# Altium schematic sheet mems.SchDoc
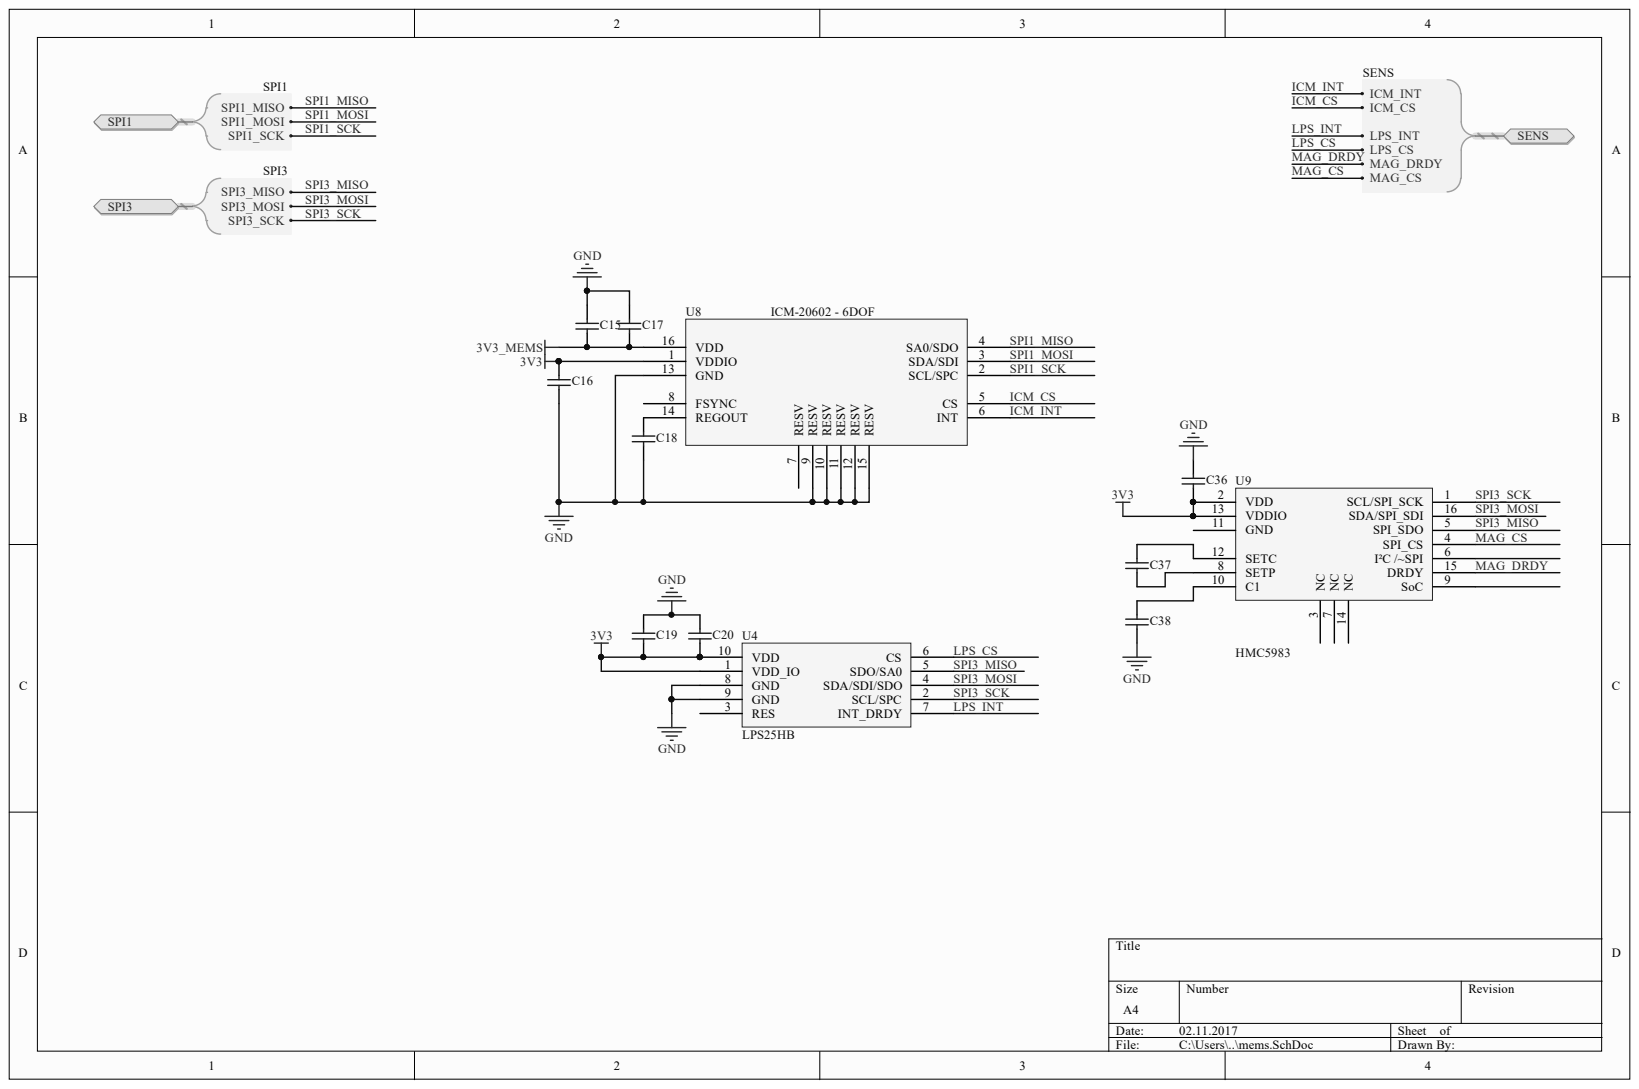
[diagram p0: mems.SchDoc]

## Parts
C15  CAP 100nF 35V 0402(1005)  [CAPC0402(1005)60_M]  (value not drawn)
C16  CAP 10nF 35V 0402(1005)  [CAPC0402(1005)60_M]  (value not drawn)
C17  CAP 2.2uF 16V 0603(1608)  [CAPC0603(1608)55_L]  (value not drawn)
C18  CAP 100nF 25V 0402(1005)  [CAPC0402(1005)60_L]  (value not drawn)
C19  CAP 1uF 6.3V 0603(1608)  [CAPC0603(1608)100_L]  (value not drawn)
C20  CAP 100nF 35V 0402(1005)  [CAPC0402(1005)60_L]  (value not drawn)
C36  CAP 100nF 35V 0402(1005)  [CAPC0402(1005)60_L]  (value not drawn)
C37  CAP 220nF 25V 0402(1005)  [CAPC0402(1005)60_L]  (value not drawn)
C38  CAP 4.7uF 16V 0603(1608)  [CAPC0603(1608)100_L]  (value not drawn)
U4  LPS25HB  [HLGA10]
U8  ICM-20602 - 6DOF  [LCC16]
U9  HMC5983  [LCC16]

## Ports
SENS, SENS.ICM_CS, SENS.ICM_INT, SENS.LPS_CS, SENS.LPS_INT, SENS.MAG_CS, SENS.MAG_DRDY, SPI1, SPI1.SPI1_MISO, SPI1.SPI1_MOSI, SPI1.SPI1_SCK, SPI3, SPI3.SPI3_MISO, SPI3.SPI3_MOSI, SPI3.SPI3_SCK

## Nets
3V3: C16.2 C19.1 C20.1 C36.1 U4.1(VDD_IO) U4.10(VDD) U8.1(VDDIO) U9.2(VDD) U9.13(VDDIO)
3V3_MEMS: C15.1 C17.1 U8.16(VDD)
GND: C15.2 C16.1 C17.2 C18.1 C19.2 C20.2 C36.2 C38.1 U4.8(GND) U4.9(GND) U8.9(RESV) U8.10(RESV) U8.11(RESV) U8.12(RESV) U8.13(GND) U8.15(RESV)
ICM_CS: U8.5(CS) ; port SENS
ICM_INT: U8.6(INT) ; port SENS
LPS_CS: U4.6(CS) ; port SENS
LPS_INT: U4.7(INT_DRDY) ; port SENS
MAG_CS: U9.4(SPI_CS) ; port SENS
MAG_DRDY: U9.15(DRDY) ; port SENS
SPI1_MISO: U8.4(SA0/SDO) ; port SPI1
SPI1_MOSI: U8.3(SDA/SDI) ; port SPI1
SPI1_SCK: U8.2(SCL/SPC) ; port SPI1
SPI3_MISO: U4.5(SDO/SA0) U9.5(SPI_SDO) ; port SPI3
SPI3_MOSI: U4.4(SDA/SDI/SDO) U9.16(SDA/SPI_SDI) ; port SPI3
SPI3_SCK: U4.2(SCL/SPC) U9.1(SCL/SPI_SCK) ; port SPI3
NetC18_2: C18.2 U8.14(REGOUT)
NetC37_1: C37.1 U9.12(SETC)
NetC37_2: C37.2 U9.8(SETP)
NetC38_2: C38.2 U9.10(C1)
NetU4_3: U4.3(RES)
NetU8_7: U8.7(RESV)
NetU8_8: U8.8(FSYNC)
NetU9_3: U9.3(NC)
NetU9_6: U9.6(I²C_/~SPI)
NetU9_7: U9.7(NC)
NetU9_9: U9.9(SoC)
NetU9_11: U9.11(GND)
NetU9_14: U9.14(NC)

## Library data of the parts
C15 C20 C36 = CMP-1034-02075-1: CAP 100nF 35V ±10% 0402 (1005 Metric) Thickness 0.6mm SMD; Case-EIA: 0402; Case-Metric: 1005; Tolerance: Â±10%; Component Kind: Standard; Library Name: Altium Content Vault; Max Thickness: 0.6 mm; Rated Voltage: 35 V; Technology: SMT; Value: 100nF
C16 = CMP-1034-01883-1: CAP 10nF 35V ±10% 0402 (1005 Metric) Thickness 0.6mm SMD; Case-EIA: 0402; Case-Metric: 1005; Tolerance: Â±10%; Component Kind: Standard; Library Name: Altium Content Vault; Max Thickness: 0.6 mm; Rated Voltage: 35 V; Technology: SMT; Value: 10nF
C17 = CMP-1035-02764-1: CAP 2.2uF 16V ±20% 0603 (1608 Metric) Thickness 0.55mm SMD; Case-EIA: 0603; Case-Metric: 1608; Tolerance: Â±20%; Component Kind: Standard; Library Name: Altium Content Vault; Max Thickness: 0.55 mm; Rated Voltage: 16 V; Technology: SMT; Value: 2.2uF
C18 = CMP-1034-02073-1: CAP 100nF 25V ±20% 0402 (1005 Metric) Thickness 0.6mm SMD; Case-EIA: 0402; Case-Metric: 1005; Tolerance: Â±20%; Component Kind: Standard; Library Name: Altium Content Vault; Max Thickness: 0.6 mm; Rated Voltage: 25 V; Technology: SMT; Value: 100nF
C19 = CMP-1035-02731-1: CAP 1uF 6.3V ±20% 0603 (1608 Metric) Thickness 1mm SMD; Case-EIA: 0603; Case-Metric: 1608; Tolerance: Â±20%; Component Kind: Standard; Library Name: Altium Content Vault; Max Thickness: 1 mm; Rated Voltage: 6.3 V; Technology: SMT; Value: 1uF
C37 = CMP-1034-02093-1: CAP 220nF 25V ±20% 0402 (1005 Metric) Thickness 0.6mm SMD; Case-EIA: 0402; Case-Metric: 1005; Tolerance: Â±20%; Component Kind: Standard; Library Name: Altium Content Vault; Max Thickness: 0.6 mm; Rated Voltage: 25 V; Technology: SMT; Value: 220nF
C38 = CMP-1035-02789-1: CAP 4.7uF 16V ±20% 0603 (1608 Metric) Thickness 1mm SMD; Case-EIA: 0603; Case-Metric: 1608; Tolerance: Â±20%; Component Kind: Standard; Library Name: Altium Content Vault; Max Thickness: 1 mm; Rated Voltage: 16 V; Technology: SMT; Value: 4.7uF
U8 = ICM-20602: Component Kind: Standard; Description: ICM-20602; Library Name: invensense.SchLib
U9 = HMC5983: HMC5983 - 3 axis Compass; Component Kind: Standard; Library Name: other.SchLib
U4 = LPS25HB: Component Kind: Standard; Description: LPS25HB; Library Name: MyLib.IntLib
